# Altium schematic sheet Microcontroller.SchDoc
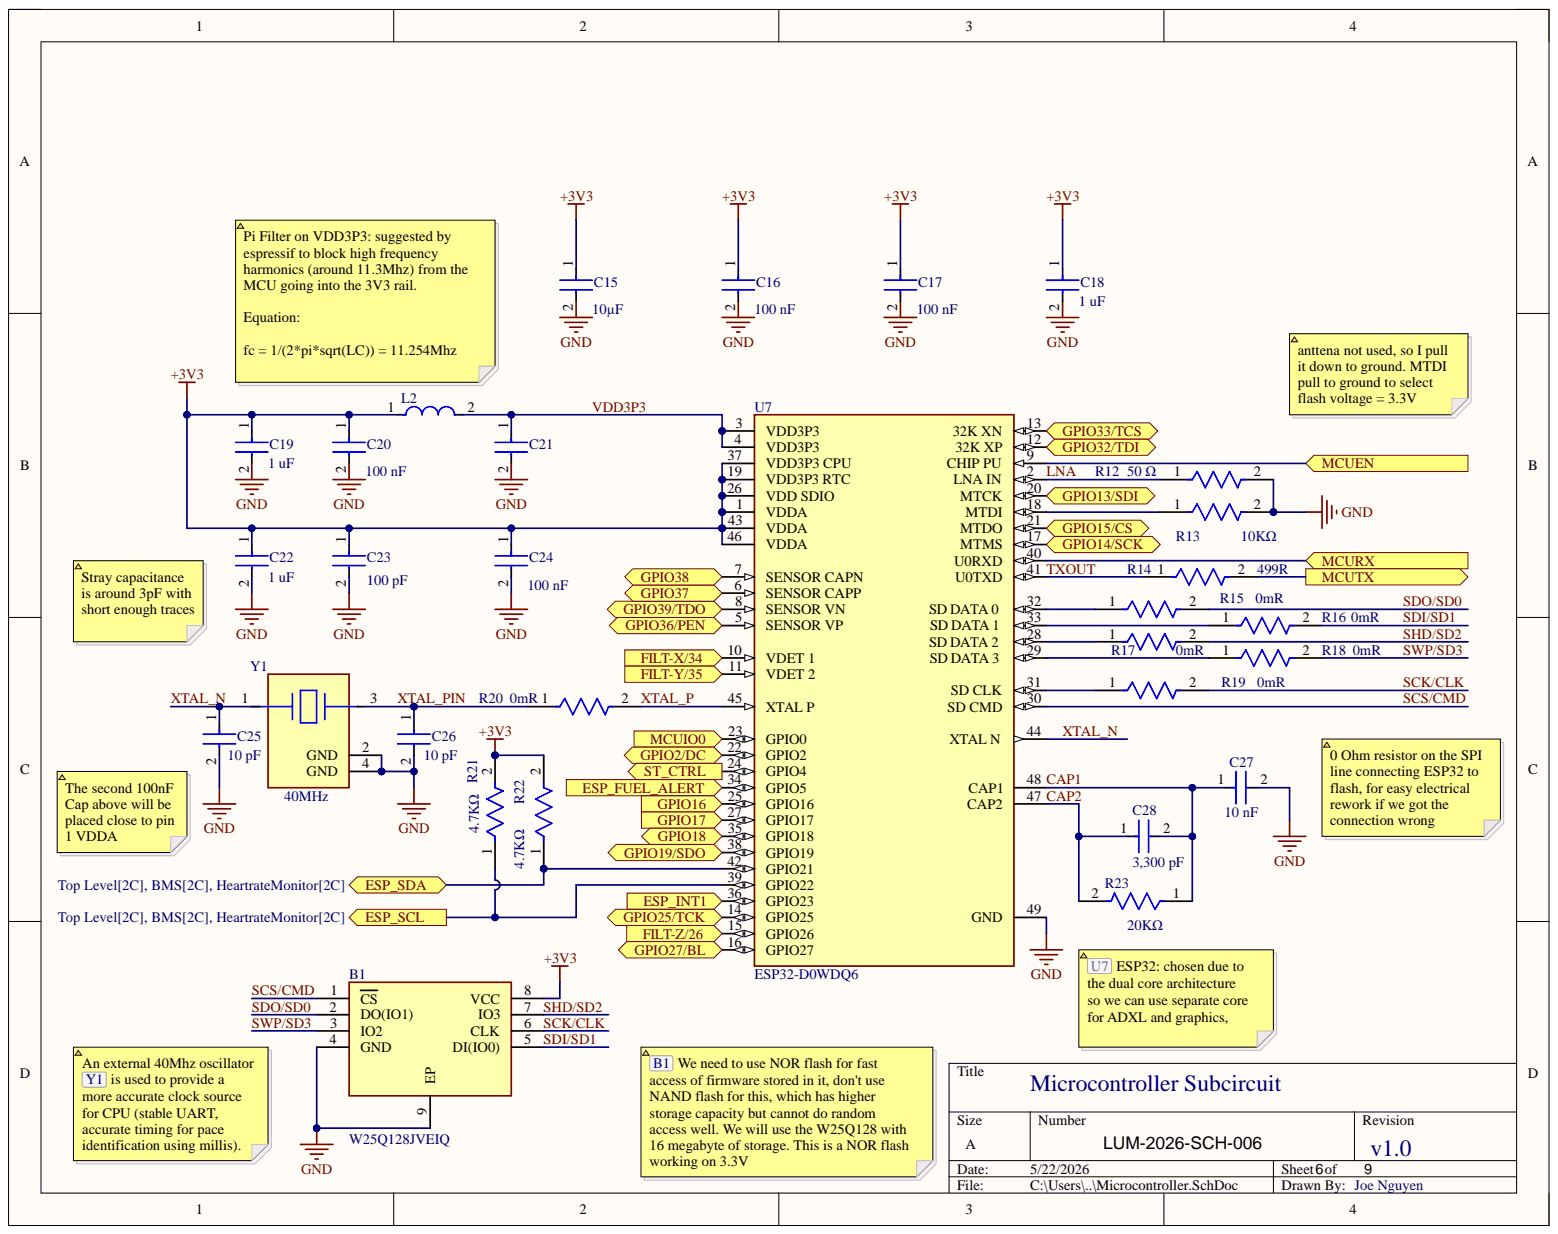
[diagram p0: Microcontroller.SchDoc]

## Parts
B1  W25Q128JVEIQ  [SON127P800X600X80-9N-D]
C15  Capacitance: 10µF  [FP-CC0603-DC-MFG]  (hidden comment: CC0603KRX5R7BB106)
C16  value 100 nF  [FP-CC0603-DA-MFG]  (hidden comment: CC0603KPX7R8BB104)
C17  value 100 nF  Capacitance: 100 nF  [FP-CC0603-DA-MFG]  (hidden comment: CC0603KPX7R8BB104)
C18  value 1 uF  Capacitance: 1 uF  [FP-CC0603-DA-MFG]  (hidden comment: CC0603KPX7R7BB105)
C19  value 1 uF  Capacitance: 1 uF  [FP-CC0603-DA-MFG]  (hidden comment: CC0603KPX7R7BB105)
C20  value 100 nF  [FP-CC0603-DA-MFG]  (hidden comment: CC0603KPX7R8BB104)
C21  CC0603KPX7R8BB104  [FP-CC0603-DA-MFG]  (value not drawn)
C22  value 1 uF  Capacitance: 1 uF  [FP-CC0603-DA-MFG]  (hidden comment: CC0603KPX7R7BB105)
C23  value 100 pF  [FP-CC0603-DA-MFG]  (hidden comment: CC0603KRX7R8BB101)
C24  value 100 nF  Capacitance: 100 nF  [FP-CC0603-DA-MFG]  (hidden comment: CC0603KPX7R8BB104)
C25  value 10 pF  [FP-C0603C-CF-MFG]  (hidden comment: C0603C100G5GACTU)
C26  value 10 pF  Capacitance: 10 pF  [FP-C0603C-CF-MFG]  (hidden comment: C0603C100G5GACTU)
C27  value 10 nF  [FP-C0603C-CF-MFG]  (hidden comment: C0603C103K3RACTU)
C28  value 3,300 pF  Capacitance: 3,300 pF  [FP-C0603C-CF-MFG]  (hidden comment: C0603C332J5RACTU)
L2  LQW04AN2N0C00D  [FP-LQW04AN_00-MFG]  (value not drawn)
R12  50 Ω  [FP-FC0603-IPC_B]
R13  value 10KΩ  Resistance: 10KΩ  [FP-CPF0603-MFG]  (hidden comment: CPF0603D10KE)
R14  Resistance: 499R  [FP-AF0603-IPC_B]  (hidden comment: AF0603FR-07499RL)
R15  AC0603JR-130RL  [FP-AC0603-IPC_A]  (value not drawn)
R16  Resistance: 0mR  [FP-AC0603-IPC_A]  (hidden comment: AC0603JR-130RL)
R17  Resistance: 0mR  [FP-AC0603-IPC_A]  (hidden comment: AC0603JR-130RL)
R18  Resistance: 0mR  [FP-AC0603-IPC_A]  (hidden comment: AC0603JR-130RL)
R19  AC0603JR-130RL  [FP-AC0603-IPC_A]  (value not drawn)
R20  Resistance: 0mR  [FP-AC0603-IPC_A]  (hidden comment: AC0603JR-130RL)
R21  value 4.7KΩ  Resistance: 4.7KΩ  [FP-RT0603-MFG]  (hidden comment: RT0603BRE074K7L)
R22  value 4.7KΩ  Resistance: 4.7KΩ  [FP-RT0603-MFG]  (hidden comment: RT0603BRE074K7L)
R23  value 20KΩ  Resistance: 20KΩ  [FP-RT0603-MFG]  (hidden comment: RT0603DRD0720KL)
U7  ESP32-D0WDQ6  [FP-ESP32-D0WDQ6-IPC_C]
Y1  Freq: 40MHz  [FP-ABM10W-MFG]  (hidden comment: ABM10W-40.0000MHZ-8-B1U-T3)

## Ports
ESP_FUEL_ALERT, ESP_INT1, ESP_SCL, ESP_SDA, FILT-X/34, FILT-Y/35, FILT-Z/26, GPIO2/DC, GPIO13/SDI, GPIO14/SCK, GPIO15/CS, GPIO16, GPIO17, GPIO18, GPIO19/SDO, GPIO25/TCK, GPIO27/BL, GPIO32/TDI, GPIO33/TCS, GPIO36/PEN, GPIO37, GPIO38, GPIO39/TDO, MCUEN, MCUIO0, MCURX, MCUTX, ST_CTRL

## Nets
+3V3: B1.8(VCC) C15.1 C16.1 C17.1 C18.1 C19.1 C20.1 C22.1 C23.1 C24.1 L2.1 R21.2 R22.2 U7.1(VDDA) U7.19(VDD3P3_RTC) U7.26(VDD_SDIO) U7.37(VDD3P3_CPU) U7.43(VDDA) U7.46(VDDA)
GND: B1.4(GND) B1.9(EP) C15.2 C16.2 C17.2 C18.2 C19.2 C20.2 C21.2 C22.2 C23.2 C24.2 C25.2 C26.2 C27.2 R12.2 R13.2 U7.49(GND) Y1.2(GND) Y1.4(GND)
CAP1: C27.1 C28.2 R23.1 U7.48(CAP1)
CAP2: C28.1 R23.2 U7.47(CAP2)
ESP_FUEL_ALERT: U7.34(GPIO5) ; port ESP_FUEL_ALERT
ESP_INT1: U7.36(GPIO23) ; port ESP_INT1
ESP_SCL: R21.1 U7.39(GPIO22) ; port ESP_SCL
ESP_SDA: R22.1 U7.42(GPIO21) ; port ESP_SDA
FILT-X/34: U7.10(VDET_1) ; port FILT-X/34
FILT-Y/35: U7.11(VDET_2) ; port FILT-Y/35
FILT-Z/26: U7.15(GPIO26) ; port FILT-Z/26
GPIO2/DC: U7.22(GPIO2) ; port GPIO2/DC
GPIO13/SDI: U7.20(MTCK) ; port GPIO13/SDI
GPIO14/SCK: U7.17(MTMS) ; port GPIO14/SCK
GPIO15/CS: U7.21(MTDO) ; port GPIO15/CS
GPIO16: U7.25(GPIO16) ; port GPIO16
GPIO17: U7.27(GPIO17) ; port GPIO17
GPIO18: U7.35(GPIO18) ; port GPIO18
GPIO19/SDO: U7.38(GPIO19) ; port GPIO19/SDO
GPIO25/TCK: U7.14(GPIO25) ; port GPIO25/TCK
GPIO27/BL: U7.16(GPIO27) ; port GPIO27/BL
GPIO32/TDI: U7.12(32K_XP) ; port GPIO32/TDI
GPIO33/TCS: U7.13(32K_XN) ; port GPIO33/TCS
GPIO36/PEN: U7.5(SENSOR_VP) ; port GPIO36/PEN
GPIO37: U7.6(SENSOR_CAPP) ; port GPIO37
GPIO38: U7.7(SENSOR_CAPN) ; port GPIO38
GPIO39/TDO: U7.8(SENSOR_VN) ; port GPIO39/TDO
LNA: R12.1 U7.2(LNA_IN)
MCUEN: U7.9(CHIP_PU) ; port MCUEN
MCUIO0: U7.23(GPIO0) ; port MCUIO0
MCURX: U7.40(U0RXD) ; port MCURX
MCUTX: R14.2 ; port MCUTX
SCK/CLK: B1.6(CLK) R19.2
SCS/CMD: B1.1(CS) U7.30(SD_CMD)
SDI/SD1: B1.5(DI(IO0)) R16.2
SDO/SD0: B1.2(DO(IO1)) R15.2
SHD/SD2: B1.7(IO3) R17.2
ST_CTRL: U7.24(GPIO4) ; port ST_CTRL
SWP/SD3: B1.3(IO2) R18.2
TXOUT: R14.1 U7.41(U0TXD)
VDD3P3: C21.1 L2.2 U7.3(VDD3P3) U7.4(VDD3P3)
XTAL_N: C25.1 U7.44(XTAL_N) Y1.1
XTAL_P: R20.2 U7.45(XTAL_P)
XTAL_PIN: C26.1 R20.1 Y1.3
NetR13_1: R13.1 U7.18(MTDI)
NetR15_1: R15.1 U7.32(SD_DATA_0)
NetR16_1: R16.1 U7.33(SD_DATA_1)
NetR17_1: R17.1 U7.28(SD_DATA_2)
NetR18_1: R18.1 U7.29(SD_DATA_3)
NetR19_1: R19.1 U7.31(SD_CLK)

## Library data of the parts
B1 = W25Q128JVEIQ: 128M-bit Serial Flash Memory with uniform 4KB sectors and Dual/Quad SPI; Manufacturer_Name: Winbond; Geometry.Height: 0.8mm; Arrow Part Number: W25Q128JVEIQ; Arrow Price/Stock: https://www.arrow.com/en/products/w25q128jveiq/winbond-electronics?utm_currency=USD&region=nac; Component Kind: Standard; Datasheet Link: https://www.winbond.com/hq/search-resource-file.jsp?partNo=W25Q128JVEIQ&type=datasheet; Library Name: Student_Schlib_41070.SchLib; Manufacturer_Part_Number: W25Q128JVEIQ; Mouser Part Number: 454-W25Q128JVEIQ; Mouser Price/Stock: https://www.mouser.co.uk/ProductDetail/Winbond/W25Q128JVEIQ?qs=qSfuJ%252Bfl%2Fd667JrLNi7hwg%3D%3D; Supplier 1: DigiKey; Supplier Part Number 1: 256-W25Q128JVEIQ-TUBE-ND
C15 = CMP-00621-81932632-1: CC0603KRX5R7BB106 Multilayer Ceramic Capacitor, 10 uF, 16 V, ± 10%, X5R, 0603 [1608 Metric]; Voltage Rating: 16V; Case/Package: 0603; Component Kind: Standard; Dielectric: X5R; Halogen Free: Halogen Free; Height: 1mm; Lead Free: Lead Free; Library Name: Altium Content Vault; Material: Ceramic; Max Operating Temperature: 85°C; Min Operating Temperature: -55°C; NexarPartId: 81932632; RoHS: Non-Compliant; Tolerance: 10%; Voltage Rating (DC): 16V
C16 C17 C20 C24 = CMP-03422-000081-1: CC0603 100 nF X7R 10.00% 25 V; Manufacturer: YAGEO; Material: Ceramic; Temperature Coefficient: 15%; Tolerance: 0.1; Voltage: 25 V; Automotive: No; Category: Cap; Component Kind: Standard; ComponentLink2Description: Datasheet; ComponentLink2URL: http://www.yageo.com/documents/recent/UPY-GPHC_X7R_6.3V-to-50V_18.pdf; Device Class L1: Passive Components; Device Class L2: Capacitors; Device Class L3: Ceramic Capacitors; Footprint Url: http://www.yageo.com/exep/pages/download/literatures/UPY-C_GEN_24.pdf; Height: 0.9mm; Lead Free: Yes; Library Name: Altium Content Vault; Manufacturer Part Number: CC0603KPX7R8BB104; Mouser Description: Multilayer Ceramic Capacitors MLCC - SMD/SMT 100nF 25V X7R 10%; Octopart: https://octopart.com/cc0603kpx7r8bb104-yageo-12885111; Package: CC0603-DA; RoHS: TRUE; Temperature: -55°C to +125°C; Temperature Characteristic: X7R; Temperature Range High: +125°C; Temperature Range Low: -55°C; Voltage Rating: 25 V
  C16 C20: Capacitance: 100 nF
C18 C19 C22 = CMP-03422-001047-1: CC0603 1 uF X7R 10.00% 16 V; Manufacturer: YAGEO; Material: Ceramic; Temperature Coefficient: 15%; Tolerance: 0.1; Voltage: 16 V; Automotive: No; Category: Cap; Component Kind: Standard; ComponentLink2Description: Datasheet; ComponentLink2URL: http://www.yageo.com/documents/recent/UPY-GPHC_X7R_6.3V-to-50V_18.pdf; Device Class L1: Passive Components; Device Class L2: Capacitors; Device Class L3: Ceramic Capacitors; Footprint Url: http://www.yageo.com/exep/pages/download/literatures/UPY-C_GEN_24.pdf; Height: 0.9mm; Lead Free: Yes; Library Name: Altium Content Vault; Manufacturer Part Number: CC0603KPX7R7BB105; Mouser Description: Cap Ceramic 1uF 16V X7R 10% SMD 0603 125°C Paper T/R; Octopart: https://octopart.com/cc0603kpx7r7bb105-yageo-25629533; Package: CC0603-DA; RoHS: TRUE; Temperature: -55°C to +125°C; Temperature Characteristic: X7R; Temperature Range High: +125°C; Temperature Range Low: -55°C; Voltage Rating: 16 V
C21 = CMP-03422-000081-1: CC0603 100 nF X7R 10.00% 25 V; Manufacturer: YAGEO; Capacitance: 100 nF; Material: Ceramic; Temperature Coefficient: 15%; Tolerance: 0.1; Value: 100 nF; Voltage: 25 V; Automotive: No; Category: Cap; Component Kind: Standard; ComponentLink2Description: Datasheet; ComponentLink2URL: http://www.yageo.com/documents/recent/UPY-GPHC_X7R_6.3V-to-50V_18.pdf; Device Class L1: Passive Components; Device Class L2: Capacitors; Device Class L3: Ceramic Capacitors; Footprint Url: http://www.yageo.com/exep/pages/download/literatures/UPY-C_GEN_24.pdf; Height: 0.9mm; Lead Free: Yes; Library Name: Altium Content Vault; Manufacturer Part Number: CC0603KPX7R8BB104; Mouser Description: Multilayer Ceramic Capacitors MLCC - SMD/SMT 100nF 25V X7R 10%; Octopart: https://octopart.com/cc0603kpx7r8bb104-yageo-12885111; Package: CC0603-DA; RoHS: TRUE; Temperature: -55°C to +125°C; Temperature Characteristic: X7R; Temperature Range High: +125°C; Temperature Range Low: -55°C; Voltage Rating: 25 V
C23 = CMP-03422-001004-1: CAP CER 100PF 25V X7R 0603; Manufacturer: YAGEO; Material: Ceramic; Temperature Coefficient: 15%; Tolerance: 0.1; Automotive: No; Capacitance: 100 pF; Category: Cap; Component Kind: Standard; ComponentLink2Description: Datasheet; ComponentLink2URL: http://www.yageo.com/documents/recent/UPY-GPHC_X7R_6.3V-to-50V_18.pdf; Device Class L1: Passive Components; Device Class L2: Capacitors; Device Class L3: Ceramic Capacitors; Digikey Description: CAP CER 100PF 25V X7R 0603; Footprint Url: http://www.yageo.com/exep/pages/download/literatures/UPY-C_GEN_24.pdf; Height: 0.9mm; Lead Free: Yes; Library Name: Altium Content Vault; Manufacturer Part Number: CC0603KRX7R8BB101; Mouser Description: Cap Ceramic 100pF 25V X7R 10% SMD 0603 125°C Paper T/R; Octopart: https://octopart.com/cc0603krx7r8bb101-yageo-33242295; Package: CC0603-DA; RoHS: TRUE; Temperature: -55°C to +125°C; Temperature Characteristic: X7R; Temperature Range High: +125°C; Temperature Range Low: -55°C; Voltage: 25 V; Voltage Rating: 25 V
C25 = CMP-03020-027293-1: C0603 10 pF C0G 30ppm/°C 2.00% 50 V; Manufacturer: KEMET; Automotive Grade: Grade 1; Capacitance: 10 pF; Material: Ceramic; Tolerance: 0.02; Value: 10 pF; Voltage Rating: 50 V; Automotive: Yes; Category: Cap; Component Kind: Standard; ComponentLink2Description: Datasheet; ComponentLink2URL: https://api.kemet.com/component-edge/download/datasheet/C0603C100J5GACAUTO.pdf; Device Class L1: Passive Components; Device Class L2: Capacitors; Device Class L3: Ceramic Capacitors; Height: 0.87mm; Lead Free: Yes; Library Name: Altium Content Vault; Manufacturer Part Number: C0603C100G5GACTU; Mouser Description: Cap Ceramic 10pF 50V C0G 2% SMD 0603 125°C Paper T/R; Octopart: https://octopart.com/c0603c100g5gactu-kemet-61593593; Package: C0603C-CF; RoHS: TRUE; Temperature: -55°C to +125°C; Temperature Characteristic: C0G; Temperature Coefficient: 30ppm/°C; Temperature Range High: +125°C; Temperature Range Low: -55°C
C26 = CMP-03020-027293-1: C0603 10 pF C0G 30ppm/°C 2.00% 50 V; Manufacturer: KEMET; Automotive Grade: Grade 1; Material: Ceramic; Tolerance: 0.02; Voltage Rating: 50 V; Automotive: Yes; Category: Cap; Component Kind: Standard; ComponentLink2Description: Datasheet; ComponentLink2URL: https://api.kemet.com/component-edge/download/datasheet/C0603C100J5GACAUTO.pdf; Device Class L1: Passive Components; Device Class L2: Capacitors; Device Class L3: Ceramic Capacitors; Height: 0.87mm; Lead Free: Yes; Library Name: Altium Content Vault; Manufacturer Part Number: C0603C100G5GACTU; Mouser Description: Cap Ceramic 10pF 50V C0G 2% SMD 0603 125°C Paper T/R; Octopart: https://octopart.com/c0603c100g5gactu-kemet-61593593; Package: C0603C-CF; RoHS: TRUE; Temperature: -55°C to +125°C; Temperature Characteristic: C0G; Temperature Coefficient: 30ppm/°C; Temperature Range High: +125°C; Temperature Range Low: -55°C
C27 = CMP-2006-03040-2: CAP CER 10000PF 25V 10% X7R 0603; Manufacturer: KEMET; Automotive Grade: Grade 1; Capacitance: 10,000 pF; Material: Ceramic; Temperature Coefficient: 30ppm/°C; Tolerance: 0.1; Automotive: Yes; Category: Cap; Component Kind: Standard; ComponentLink2Description: Datasheet; ComponentLink2URL: https://api.kemet.com/component-edge/download/datasheet/C0603C100J5GACAUTO.pdf; Device Class L1: Passive Components; Device Class L2: Capacitors; Device Class L3: Ceramic Capacitors; Digikey Description: CAP CER 10000PF 25V 10% X7R 0603; Height: 0.87mm; Lead Free: Yes; Library Name: Altium Content Vault; Manufacturer Part Number: C0603C103K3RACTU; Mouser Description: Multilayer Ceramic Capacitors MLCC - SMD/SMT 25volts 10000pF X7R 10%; Octopart: https://octopart.com/c0603c103k3ractu-kemet-89103; Package: C0603C-CF; RoHS: TRUE; Temperature: -55°C to +125°C; Temperature Characteristic: X7R; Temperature Range High: +125°C; Temperature Range Low: -55°C; Voltage Rating: 25 V
C28 = CMP-2006-03174-2: CAP CER 3300PF 50V 5% X7R 0603; Manufacturer: KEMET; Automotive Grade: Grade 1; Material: Ceramic; Temperature Coefficient: 30ppm/°C; Tolerance: 0.05; Automotive: Yes; Category: Cap; Component Kind: Standard; ComponentLink2Description: Datasheet; ComponentLink2URL: https://api.kemet.com/component-edge/download/datasheet/C0603C100J5GACAUTO.pdf; Device Class L1: Passive Components; Device Class L2: Capacitors; Device Class L3: Ceramic Capacitors; Digikey Description: CAP CER 3300PF 50V 5% X7R 0603; Height: 0.87mm; Lead Free: Yes; Library Name: Altium Content Vault; Manufacturer Part Number: C0603C332J5RACTU; Mouser Description: Multilayer Ceramic Capacitors MLCC - SMD/SMT 50volts 3300pF X7R 5%; Octopart: https://octopart.com/c0603c332j5ractu-kemet-9139905; Package: C0603C-CF; RoHS: TRUE; Temperature: -55°C to +125°C; Temperature Characteristic: X7R; Temperature Range High: +125°C; Temperature Range Low: -55°C; Voltage Rating: 50 V
L2 = CMP-06042-014585-1: Wire Wound RF Inductor 2nH ±0.2nH 1100mA 0.03Ω 03015 (0804); Component Kind: Standard; Library Name: Altium Content Vault
R12 = CMP-02407-013076-1: RES SMD 50 OHM 0.1% 1/8W 0603; Component Kind: Standard; Library Name: Altium Content Vault
R13 = CMP-03211-003506-1: RES SMD 10KΩ 0.5% 1/16W 0603; Manufacturer: TE Connectivity; Power Rating: 0.063W; Temperature Coefficient: 25ppm/°C; Voltage Rating: 50V; Automotive: No; Category: Res; Component Kind: Standard; ComponentLink2Description: Datasheet; ComponentLink2URL: https://www.te.com/commerce/DocumentDelivery/DDEController?Action=showdoc&DocId=Data+Sheet%7F1773200%7FM%7Fpdf%7FEnglish%7FENG_DS_1773200_M.pdf%7F3-1676481-2; Composition: Thin Film; Device Class L1: Passive Components; Device Class L2: Resistors; Device Class L3: Chip SMD Resistors; Height: 0.55mm; Ipc Land Pattern Name: RESC15580X45; Lead Free: Yes; Library Name: Altium Content Vault; Manufacturer Part Number: CPF0603D10KE; Octopart: https://octopart.com/cpf0603d10ke-te+connectivity-22737789; octopart_url: https://octopart.com/cpf0603d10ke-te+connectivity-22737789; Package: CPF0603; packaging: 5k Reel; RoHS: TRUE; Series: CPF; Temperature: -55°C to +155°C; Temperature Range High: +155°C; Temperature Range Low: -55°C; Tolerance: 0.5%
R14 = CMP-03412-048261-1: Anti-Sulfurated Chip Resistors Automotive Grade 499Ω 1% 100ppm/°C 0603; Max Power Dissipation: 100mW; Case/Package: 0603; Component Kind: Standard; Features: Moisture Resistant; Height: 0.022inch; Length: 0.063inch; Library Name: Altium Content Vault; Max Operating Temperature: 155°C; Min Operating Temperature: -55°C; Number of Terminations: 2; Packaging: Tape and Reel; Resistor Type: Thick Film; RoHS Compliant: Yes; Series: AF; Temperature Coefficient: 100ppm/°C; Tolerance: 1%; Width: 0.031inch
R15 R19 = CMP-03412-047235-1: RES 0Ω 0.00% 0.1W 0603; Resistance: 0mR; Tolerance: 5%; Case/Package: 0603; Component Kind: Standard; Lead Free: Lead Free; Library Name: Altium Content Vault; RoHS Compliant: Yes
R16-R18 R20 = CMP-03412-047235-1: RES 0Ω 0.00% 0.1W 0603; Tolerance: 5%; Case/Package: 0603; Component Kind: Standard; Lead Free: Lead Free; Library Name: Altium Content Vault; RoHS Compliant: Yes
R21 R22 = CMP-2000-04545-2: RES SMD 4.7K OHM 0.1% 1/10W 0603; Manufacturer: Yageo; Power Rating: 0.1W; Temperature Coefficient: 50 ppm/°C; Tolerance: 0.001; Voltage Rating: 75V; Automotive: No; Category: Res; Component Kind: Standard; ComponentLink2Description: Datasheet; ComponentLink2URL: http://www.yageo.com/documents/recent/PYu-RT_1-to-0.01_RoHS_L_9.pdf; Composition: Thin Film; Device Class L1: Passive Components; Device Class L2: Resistors; Device Class L3: Chip SMD Resistors; Digikey Description: RES SMD 4.7K OHM 0.1% 1/10W 0603; Footprint Url: http://www.yageo.com/exep/pages/download/literatures/PYu-R_Mount_10.pdf; Height: 0.55mm; Ipc Land Pattern Name: RESC16080X45; Lead Free: Yes; Library Name: Altium Content Vault; Manufacturer Part Number: RT0603BRE074K7L; Octopart: https://octopart.com/rt0603bre074k7l-yageo-1207135; Package: RT0603; RoHS: TRUE; Series: RT; Temperature: -55°C to +155°C; Temperature Range High: +155°C; Temperature Range Low: -55°C
R23 = CMP-2000-04568-2: RES SMD 20K OHM 0.5% 1/10W 0603; Manufacturer: Yageo; Power Rating: 0.1W; Temperature Coefficient: 25 ppm/°C; Tolerance: 0.005; Voltage Rating: 75V; Automotive: No; Category: Res; Component Kind: Standard; ComponentLink2Description: Datasheet; ComponentLink2URL: http://www.yageo.com/documents/recent/PYu-RT_1-to-0.01_RoHS_L_9.pdf; Composition: Thin Film; Device Class L1: Passive Components; Device Class L2: Resistors; Device Class L3: Chip SMD Resistors; Digikey Description: RES SMD 20K OHM 0.5% 1/10W 0603; Footprint Url: http://www.yageo.com/exep/pages/download/literatures/PYu-R_Mount_10.pdf; Height: 0.55mm; Ipc Land Pattern Name: RESC16080X45; Lead Free: Yes; Library Name: Altium Content Vault; Manufacturer Part Number: RT0603DRD0720KL; Octopart: https://octopart.com/rt0603drd0720kl-yageo-1224030; Package: RT0603; RoHS: TRUE; Series: RT; Temperature: -55°C to +155°C; Temperature Range High: +155°C; Temperature Range Low: -55°C
U7 = CMP-194065-000007-1: IC RF TXRX+MCU BLUETOOTH 48VFQFN; Current - Receiving: 100mA; Current - Transmitting: 240mA; Data Rate: 150Mbps; Frequency: 2.4GHz; Interface: I2C; Memory Size: 520KB; Number of GPIO: 34; Sensitivity: -98dBm; Component Kind: Standard; Library Name: Altium Content Vault; Max Operating Temperature: 125°C; Min Operating Temperature: -40°C; Packaging: Tape and Reel
Y1 = CMP-27762-006679-1: Crystal 40MHz ±10ppm 8pF SMD-4 2.5mm x 2mm; Component Kind: Standard; Library Name: Altium Content Vault; RoHS Compliant: Yes
